# Altium schematic sheet Power.SchDoc(Power)
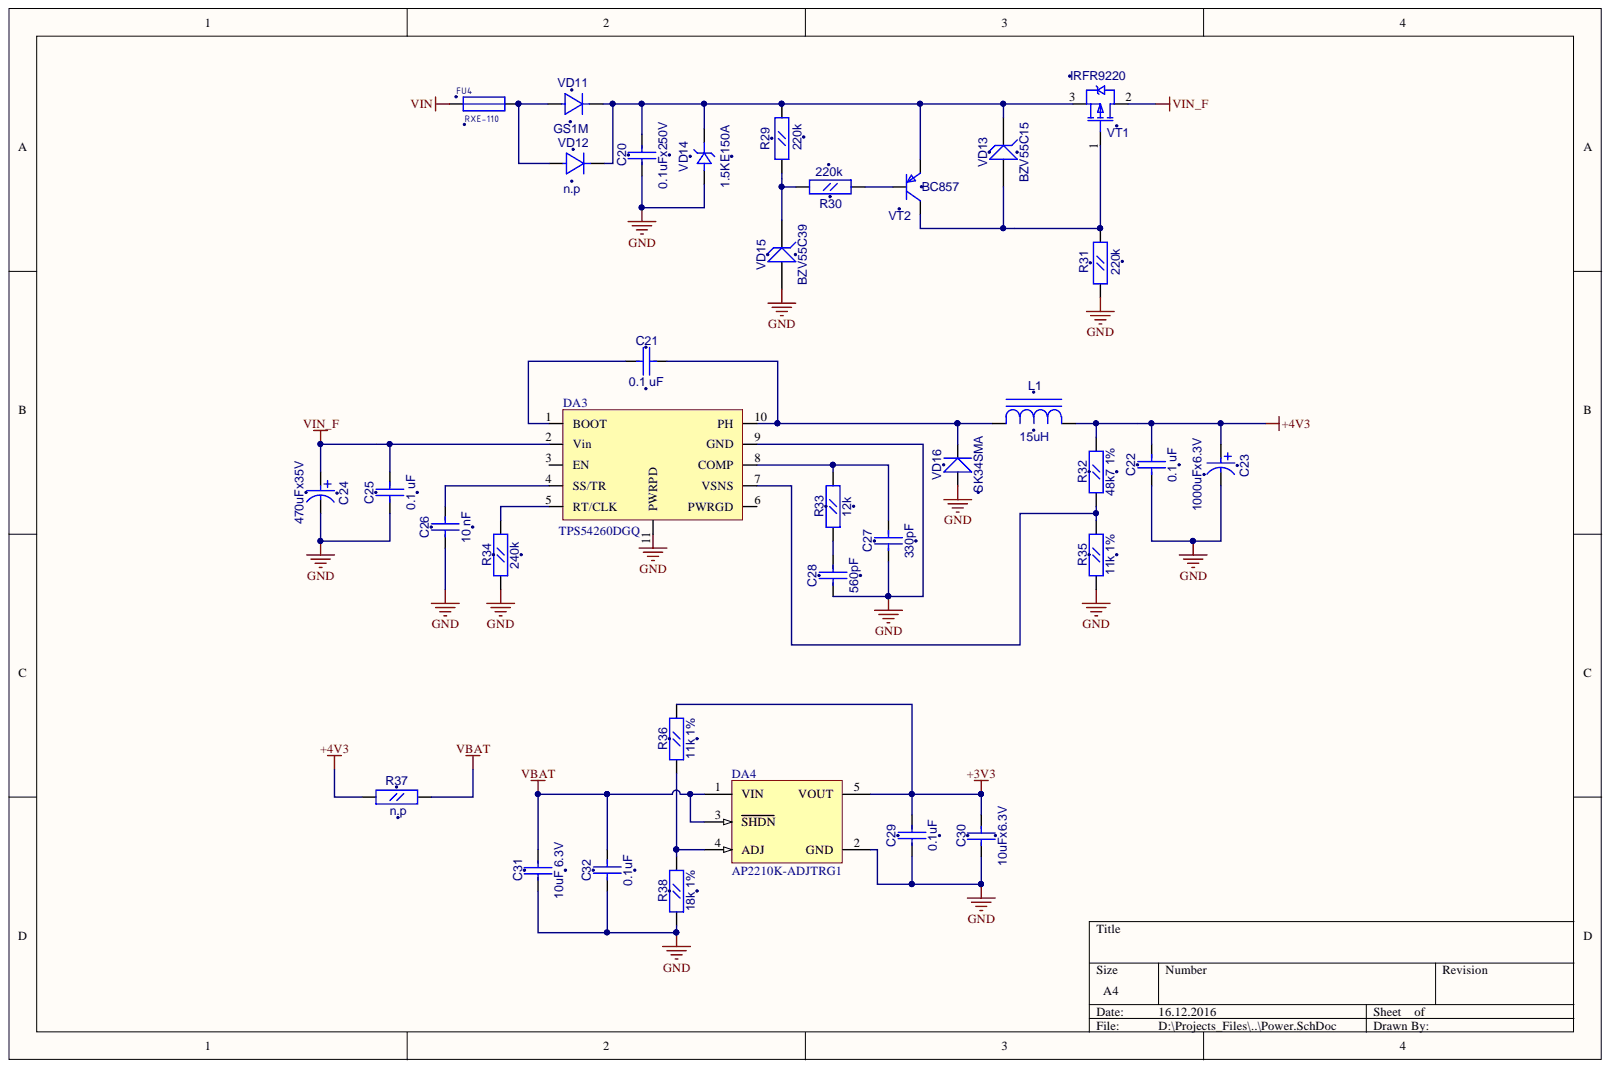
[diagram p0: Power.SchDoc]

## Parts
C20  0.1uFx250V  [CC1206]
C21  0.1 uF  [CC0603]
C22  0.1 uF  [CC0603]
C23  1000uFx6.3V  [CC8X3.5]
C24  470uFx35V  [CC16X10_CAP0.5(1)(Primary)]
C25  0.1 uF  [CC0603]
C26  10 nF  [CC0603]
C27  330pF  [CC0603]
C28  560pF  [CC0603]
C29  0.1uF  [CC0603]
C30  10uFx6.3V  [CC0805]
C31  10uF 6.3V  [CC0805]
C32  0.1uF  [CC0603]
DA3  TPS54260DGQ  [S-PDSO-G10]
DA4  AP2210K-ADJTRG1  [SOT23-5]
FU4  RXE-110  [RXE-110]
L1  15uH  [SDR0805]
R29  220k  [RC0603]
R30  220k  [RC0603]
R31  220k  [RC0603]
R32  48k7 1%  [RC0603]
R33  12k  [RC0603]
R34  240k  [RC0603]
R35  11k 1%  [RC0603]
R36  11k 1%  [RC0603]
R37  n.p  [RC0805]
R38  18k 1%  [RC0603]
VD11  GS1M  [SMA]
VD12  n.p  [SMA]
VD13  BZV55C15  [SOD-80]
VD14  1.5KE150A  [DO-201]
VD15  BZV55C39  [SOD-80]
VD16  SK34SMA  [SMA]
VT1  IRFR9220  [D-PAK(TO-252AA)]
VT2  BC857  [SOT-23(123)]

## Nets
+3V3: C29.1 C30.2 DA4.5(VOUT) R36.2
+4V3: C22.1 C23.1 L1.2 R32.2 R37.1
GND: C20.1 C22.2 C23.2 C24.1 C25.2 C26.2 C27.2 C28.2 C29.2 C30.1 C31.1 C32.2 DA3.9(GND) DA3.11(PWRPD) DA4.2(GND) R31.1 R34.1 R35.1 R38.1 VD14.1 VD15.1 VD16.1
VBAT: C31.2 C32.1 DA4.1(VIN) DA4.3(SHDN) R37.2
VIN: FU4.1
VIN_F: C24.2 C25.1 DA3.2(Vin) VT1.2
NetC20_2: C20.2 R29.2 VD11.2 VD12.2 VD13.2 VD14.2 VT1.3 VT2.2
NetC21_1: C21.1 DA3.10(PH) L1.1 VD16.2
NetC21_2: C21.2 DA3.1(BOOT)
NetC26_1: C26.1 DA3.4(SS/TR)
NetC27_1: C27.1 DA3.8(COMP) R33.2
NetC28_1: C28.1 R33.1
NetDA3_5: DA3.5(RT/CLK) R34.2
NetDA3_7: DA3.7(VSNS) R32.1 R35.2
NetDA4_4: DA4.4(ADJ) R36.1 R38.2
NetFU4_2: FU4.2 VD11.1 VD12.1
NetR29_1: R29.1 R30.2 VD15.2
NetR30_1: R30.1 VT2.1
NetR31_2: R31.2 VD13.1 VT1.1 VT2.3

Not connected: DA3 3(EN) 6(PWRGD)

## Library data of the parts
C20 = CC1206: Component Kind: Standard; Description: CC1206; Value: 0.1uFx250V; Library Name: gprs_modem_2.SchLib
C21 C22 C25-C29 C32 = CC0603: Component Kind: Standard; Description: CC0603; Library Name: gprs_modem_2.SchLib
  C21 C22 C25: Value: 0.1 uF
  C26: Value: 10 nF
  C27: Value: 330pF
  C28: Value: 560pF
  C29 C32: Value: 0.1uF
C23 = CAP0.35: Component Kind: Standard; Description: CAP0.35; Value: 1000uFx6.3V; Library Name: gprs_modem_2.SchLib
C24 = CAP0.5(1): Component Kind: Standard; Description: CAP0.5(1); Value: 470uFx35V; Library Name: gprs_modem_2.SchLib
C30 C31 = CC0805: Component Kind: Standard; Description: CC0805; Library Name: gprs_modem_2.SchLib
  C30: Value: 10uFx6.3V
  C31: Value: 10uF 6.3V
DA4 = MCP1824T-ADJE/OT: 300 mA, Low Voltage, Low Quiescent Current LDO Regulator, 5-Pin SOT-223, Extended Temperature, Tape and Reel; Component Kind: Standard; ComponentLink1Description: Manufacturer Link; ComponentLink1URL: http://www.microchip.com; ComponentLink2Description: Datasheet; ComponentLink2URL: http://ww1.microchip.com/downloads/en/DeviceDoc/22070a.pdf; ComponentLink3Description: Packaging Specification; ComponentLink3URL: http://ww1.microchip.com/downloads/en/PackagingSpec/00049AW.pdf; DatasheetVersion: revA, Aug-2007; PackageDescription: 5-Lead Plastic Small Outline Transistor (DC) [SOT-223]; PackageReference: SOT-223-DC5; PackageVersion: revAW, Oct-2008; Published: 29-Jan-2009; Library Name: gprs_modem_2.SchLib; Publisher: Altium Limited
L1 = SDR0805: Component Kind: Standard; Description: SDR0805; Value: 15uH; Library Name: gprs_modem_2.SchLib
R29-R36 R38 = RC0603: Component Kind: Standard; Description: RC0603; Library Name: gprs_modem_2.SchLib
  R29-R31: Value: 220k
  R32: Value: 48k7 1%
  R33: Value: 12k
  R34: Value: 240k
  R35 R36: Value: 11k 1%
  R38: Value: 18k 1%
R37 = RC0805: Component Kind: Standard; Description: RC0805; Value: n.p; Library Name: gprs_modem_2.SchLib
VD11 = GS1M: Value: {Value}; Component Kind: Standard; Description: GS1M; Library Name: gprs_modem_2.SchLib
VD12 = GS1M: Component Kind: Standard; Description: GS1M; Value: n.p; Library Name: gprs_modem_2.SchLib
VD13 = BZV55C12: Zener Voltage Regulator Diode; Component Kind: Standard; Simulation: BZX84C10LT1; Value: BZV55C15; Library Name: gprs_modem_2.SchLib
VD14 = 1.5KE: Component Kind: Standard; Description: 1.5KE; Value: 1.5KE150A; Library Name: gprs_modem_2.SchLib
VD15 = BZV55C12: Zener Voltage Regulator Diode; ComponentField6: Pin 2 is not connected and not drawn.; ComponentField7: Published 29-Sep-2000; OriginalName: BXV55C39; Component Kind: Standard; Simulation: BZX84C10LT1; Value: BZV55C39; Library Name: gprs_modem_2.SchLib
VD16 = SK34SMA: Schottky Rectifier; Value: {Value}; Component Kind: Standard; Library Name: gprs_modem.SchLib
VT1 = IRFR9220: HEXFET Power MOSFET; ComponentField7: Published 8-Jun-2000; OriginalName: IRLML5103; Component Kind: Standard; Value: IRFR9220; Library Name: gprs_modem_2.SchLib
VT2 = BC857B: PNP 100ma transistor; ComponentField7: Published 29-Sep-2000; OriginalName: BC846BLT1; Component Kind: Standard; Simulation: BC846BLT1; Value: BC857; Library Name: gprs_modem_2.SchLib
DA3 = TPS54260DGQ: Component Kind: Standard; Library Name: gprs_modem_2.SchLib
FU4 = RXE-110: Component Kind: Standard; Description: RXE-110; Library Name: gprs_modem_2.SchLib
